# Altium schematic sheet main.SchDoc(main) (continued)

## Library data of the parts
C1 = A759KR227M1VAAE024: Cap, 220Uf, 35V, Alu Polymer, Radial Rohs Compliant: Yes |Kemet A759KR227M1VAAE024; Manufacturer: KEMET; ESR (Equivalent Series Resistance): 24mΩ; Leakage Current: 1.54mA; Ripple Current: 100mA; Value: 220µF; Case/Package: Radial; Category: Aluminum Electrolytic Capacitors; ClassName: 12V_TO_5V_SUPPLY; Component Kind: Standard; ComponentLink1Description: https://datasheet.octopart.com:443/A759KR227M1VAAE024-KEMET-datasheet-182036766.pdf?src-supplier=IHS; ComponentLink1URL: https://datasheet.octopart.com:443/A759KR227M1VAAE024-KEMET-datasheet-182036766.pdf?src-supplier=IHS; ComponentLink2Description: https://datasheet.octopart.com:443/A759KR227M1VAAE024-KEMET-datasheet-181955568.pdf?src-supplier=Farnell; ComponentLink2URL: https://datasheet.octopart.com:443/A759KR227M1VAAE024-KEMET-datasheet-181955568.pdf?src-supplier=Farnell; ComponentLink3Description: https://datasheet.octopart.com:443/A759KR227M1VAAE024-KEMET-datasheet-170333783.pdf?src-supplier=Newark; ComponentLink3URL: https://datasheet.octopart.com:443/A759KR227M1VAAE024-KEMET-datasheet-170333783.pdf?src-supplier=Newark; Diameter: 8mm; Length: 11.5mm; Lifecycle Status: Production; Manufacturer Part Number: A759KR227M1VAAE024; Max Operating Temperature: 125°C; Min Operating Temperature: -55°C; Number of Terminals: 2; Packaging: Bulk; Pricing: 10=0,367, 50=0,348, 100=0,328, 500=0,262, 1000=0,241, 2000=0,236 (EUR); REACH SVHC: Yes; RoHS: Compliant; Stock: 48; Supplier: Farnell; Supplier Part Number: 4071164; Terminal Pitch: 3.5mm; Voltage Rating (DC): 35V; Library Name: IMCODR Components.SchLib
C2 = EEUFC1V221B: Radial Can ALUMINUM ELECTROLYTIC CAPACITOR FC 35V 220muF ±20% 0.394Dia 10.00mm; Manufacturer: Panasonic; ESR (Equivalent Series Resistance): 90mΩ; Lead Diameter: 600µm; Lead/Base Style: Straight; Leakage Current: 3µA; Life (Hours): 3000hours; Material: Aluminium; Polarity: Polar; Ripple Current: 755mA; Value: 220µF; Case/Package: Radial; Category: Aluminum Electrolytic Capacitors; ClassName: 12V_TO_5V_SUPPLY; Component Kind: Standard; ComponentLink1Description: https://datasheet.octopart.com:443/EEU-FC1V221B-Panasonic-datasheet-154766098.pdf?src-supplier=Master+Electronics; ComponentLink1URL: https://datasheet.octopart.com:443/EEU-FC1V221B-Panasonic-datasheet-154766098.pdf?src-supplier=Master+Electronics; ComponentLink2Description: https://datasheet.octopart.com:443/EEU-FC1V221B-Panasonic-datasheet-68300023.pdf?src-supplier=Newark; ComponentLink2URL: https://datasheet.octopart.com:443/EEU-FC1V221B-Panasonic-datasheet-68300023.pdf?src-supplier=Newark; ComponentLink3Description: https://datasheet.octopart.com:443/EEU-FC1V221B-Panasonic-datasheet-13266282.pdf?src-supplier=Electro+Sonic; ComponentLink3URL: https://datasheet.octopart.com:443/EEU-FC1V221B-Panasonic-datasheet-13266282.pdf?src-supplier=Electro+Sonic; ComponentLink4Description: https://datasheet.octopart.com:443/EEU-FC1V221B-Panasonic-datasheet-22087617.pdf?src-supplier=Electro+Sonic; ComponentLink4URL: https://datasheet.octopart.com:443/EEU-FC1V221B-Panasonic-datasheet-22087617.pdf?src-supplier=Electro+Sonic; ComponentLink5Description: https://datasheet.octopart.com:443/EEU-FC1V221B-Panasonic-datasheet-10025751.pdf?src-supplier=RS+(Formerly+Allied+Electronics); ComponentLink5URL: https://datasheet.octopart.com:443/EEU-FC1V221B-Panasonic-datasheet-10025751.pdf?src-supplier=RS+(Formerly+Allied+Electronics); Contact Plating: Tin; Diameter: 10mm; Height: 12.5mm; Height - Seated (Max): 13.462mm; Impedance: 90mΩ; Lead Pitch: 5mm; Length: 12.5mm; Manufacturer Part Number: EEUFC1V221B; Max Operating Temperature: 105°C; Min Operating Temperature: -55°C; Mounting Technology: Through Hole; Pricing: 500=0,2084, 1000=0,188, 2500=0,172, 3000=0,171, 5000=0,161, 10000=0,147 (USD); Radiation Hardening: No; RoHS: Compliant; Schedule B: 8532220020, 8532220020|8532220020|8532220020|8532220020|8532220020; Stock: 17500; Supplier: Arrow Electronics; Supplier Part Number: EEU-FC1V221B; Termination: Radial; Tolerance: 20%; Voltage: 35V; Voltage Rating: 35V; Voltage Rating (DC): 35V; Width: 10mm; Library Name: IMCODR Components.SchLib
C3 = 564R60GAD22: Cera-Mite High Voltage Ceramic Disc Capacitors 2200pF 6Kvolts 20% .375 L.S. Radial; Manufacturer: Vishay; Dielectric: Z5U; Dissipation Factor: 0.2%; Lead Diameter: 810µm; Lead Length: 32mm; Lead/Base Style: Straight; Value: 2.2nF; Voltage: 6kV; Case/Package: Radial; Category: Ceramic Capacitors; China RoHS: Non-Compliant; ClassName: HV_SUPPLY; Component Kind: Standard; ComponentLink1Description: https://datasheet.octopart.com:443/564R60GAD22-Vishay-datasheet-159515080.pdf?src-supplier=IHS; ComponentLink1URL: https://datasheet.octopart.com:443/564R60GAD22-Vishay-datasheet-159515080.pdf?src-supplier=IHS; ComponentLink2Description: https://datasheet.octopart.com:443/564R60GAD22-Vishay-datasheet-81841959.pdf?src-supplier=Upverter; ComponentLink2URL: https://datasheet.octopart.com:443/564R60GAD22-Vishay-datasheet-81841959.pdf?src-supplier=Upverter; ComponentLink3Description: https://datasheet.octopart.com:443/564R60GAD22-Vishay-datasheet-12588008.pdf?src-supplier=element14+APAC; ComponentLink3URL: https://datasheet.octopart.com:443/564R60GAD22-Vishay-datasheet-12588008.pdf?src-supplier=element14+APAC; ComponentLink4Description: https://datasheet.octopart.com:443/564R60GAD22-Vishay-datasheet-62317770.pdf?src-supplier=element14+APAC; ComponentLink4URL: https://datasheet.octopart.com:443/564R60GAD22-Vishay-datasheet-62317770.pdf?src-supplier=element14+APAC; ComponentLink5Description: https://datasheet.octopart.com:443/564R60GAD22-Vishay-datasheet-12521535.pdf?src-supplier=Future+Electronics; ComponentLink5URL: https://datasheet.octopart.com:443/564R60GAD22-Vishay-datasheet-12521535.pdf?src-supplier=Future+Electronics; ComponentLink6Description: https://datasheet.octopart.com:443/564R60GAD22-Vishay-datasheet-8370124.pdf?src-supplier=Future+Electronics; ComponentLink6URL: https://datasheet.octopart.com:443/564R60GAD22-Vishay-datasheet-8370124.pdf?src-supplier=Future+Electronics; ComponentLink7Description: https://datasheet.octopart.com:443/564R60GAD22-Vishay-datasheet-12538201.pdf?src-supplier=Future+Electronics; ComponentLink7URL: https://datasheet.octopart.com:443/564R60GAD22-Vishay-datasheet-12538201.pdf?src-supplier=Future+Electronics; ComponentLink8Description: https://datasheet.octopart.com:443/564R60GAD22-Vishay-datasheet-12511014.pdf?src-supplier=Future+Electronics; ComponentLink8URL: https://datasheet.octopart.com:443/564R60GAD22-Vishay-datasheet-12511014.pdf?src-supplier=Future+Electronics; ComponentLink9Description: https://datasheet.octopart.com:443/564R60GAD22-Vishay-datasheet-8702193.pdf?src-supplier=Future+Electronics; ComponentLink9URL: https://datasheet.octopart.com:443/564R60GAD22-Vishay-datasheet-8702193.pdf?src-supplier=Future+Electronics; ComponentLink10Description: https://datasheet.octopart.com:443/564R60GAD22-Vishay-datasheet-8632260.pdf?src-supplier=Future+Electronics; ComponentLink10URL: https://datasheet.octopart.com:443/564R60GAD22-Vishay-datasheet-8632260.pdf?src-supplier=Future+Electronics; ComponentLink11Description: https://datasheet.octopart.com:443/564R60GAD22-Vishay-datasheet-8562214.pdf?src-supplier=Future+Electronics; ComponentLink11URL: https://datasheet.octopart.com:443/564R60GAD22-Vishay-datasheet-8562214.pdf?src-supplier=Future+Electronics; ComponentLink12Description: https://datasheet.octopart.com:443/564R60GAD22-Vishay-datasheet-555701.pdf?src-supplier=Future+Electronics; ComponentLink12URL: https://datasheet.octopart.com:443/564R60GAD22-Vishay-datasheet-555701.pdf?src-supplier=Future+Electronics; ComponentLink13Description: https://datasheet.octopart.com:443/564R60GAD22-Vishay-datasheet-12545917.pdf?src-supplier=_legacy+Avnet; ComponentLink13URL: https://datasheet.octopart.com:443/564R60GAD22-Vishay-datasheet-12545917.pdf?src-supplier=_legacy+Avnet; ComponentLink14Description: https://datasheet.octopart.com:443/564R60GAD22-Vishay-datasheet-24570279.pdf?src-supplier=Farnell; ComponentLink14URL: https://datasheet.octopart.com:443/564R60GAD22-Vishay-datasheet-24570279.pdf?src-supplier=Farnell; ComponentLink15Description: https://datasheet.octopart.com:443/564R60GAD22-Vishay-datasheet-154299271.pdf?src-supplier=Master+Electronics; ComponentLink15URL: https://datasheet.octopart.com:443/564R60GAD22-Vishay-datasheet-154299271.pdf?src-supplier=Master+Electronics; ComponentLink16Description: https://datasheet.octopart.com:443/564R60GAD22-Vishay-datasheet-21267914.pdf?src-supplier=Newark; ComponentLink16URL: https://datasheet.octopart.com:443/564R60GAD22-Vishay-datasheet-21267914.pdf?src-supplier=Newark; ComponentLink17Description: https://datasheet.octopart.com:443/564R60GAD22-Vishay-datasheet-5316904.pdf?src-supplier=Verical; ComponentLink17URL: https://datasheet.octopart.com:443/564R60GAD22-Vishay-datasheet-5316904.pdf?src-supplier=Verical; ComponentLink18Description: https://datasheet.octopart.com:443/564R60GAD22-Vishay-datasheet-161812.pdf?src-supplier=Mouser; ComponentLink18URL: https://datasheet.octopart.com:443/564R60GAD22-Vishay-datasheet-161812.pdf?src-supplier=Mouser; ComponentLink19Description: http://sigma.octopart.com:80/rohs_statement/Vishay-company-15.pdf?src-supplier=Compliance+Statements; ComponentLink19URL: http://sigma.octopart.com:80/rohs_statement/Vishay-company-15.pdf?src-supplier=Compliance+Statements; Composition: Ceramic; Contact Plating: Tin; Depth: 6.1mm; Diameter: 13.5mm; Dielectric Material: Ceramic; Height: 17.1mm; Height - Seated (Max): 18.288mm; Lead Free: Lead Free; Lead Pitch: 9.5mm; Length: 13.5mm; Lifecycle Status: Production; Manufacturer Part Number: 564R60GAD22; Material: Ceramic; Max Operating Temperature: 105°C; Min Operating Temperature: -25°C; Mounting Technology: PCB, Radial, Through Hole; Number of Terminals: 2; Packaging: Bulk; Pins: 2; Pricing: 1=2, 10=1,01, 100=0,996, 500=0,914, 1000=0,819, 2000=0,803 (EUR); Radiation Hardening: No; REACH SVHC: Yes; RoHS: Compliant; Schedule B: 8532230060; Stock: 10091; Supplier: Farnell; Supplier Part Number: 2395789; Terminal Pitch: 9.5mm; Termination: Radial; Tolerance: 20%; Voltage Rating: 6kV; Voltage Rating (DC): 6kV; Weight: 4.535924g; Width: 6.1mm; Library Name: IMCODR Components.SchLib
C4 C5 C8 C9 = TAJA226M010RNJ: Cap Tant Solid 22uF 10V A CASE 20%( 3.2 X 1.6 X 1.6mm) Inward L SMD 3216-18 3 Ohm 125C T/R; Manufacturer: KYOCERA AVX; Dielectric Material: Tantalum; Dissipation Factor: 8%; ESR (Equivalent Series Resistance): 3Ω; Leakage Current: 2.2µA; Life (Hours): 2000hours; Polarity: Polar; Ripple Current: 63mA; Value: 22µF; Case Code (Imperial): 1206; Case Code (Metric): 3216; Case/Package: 1206; Category: Tantalum Capacitors; China RoHS: Compliant; Component Kind: Standard; ComponentLink1Description: https://datasheet.octopart.com:443/TAJA226M010RNJ-KYOCERA-AVX-datasheet-166923940.pdf?src-supplier=Amar+Radio+Corporation; ComponentLink1URL: https://datasheet.octopart.com:443/TAJA226M010RNJ-KYOCERA-AVX-datasheet-166923940.pdf?src-supplier=Amar+Radio+Corporation; ComponentLink2Description: https://sigma.octopart.com:443/151364110/technical_drawing/AVX-TAJA226M010RNJ.pdf?src-supplier=Upverter; ComponentLink2URL: https://sigma.octopart.com:443/151364110/technical_drawing/AVX-TAJA226M010RNJ.pdf?src-supplier=Upverter; ComponentLink3Description: https://datasheet.octopart.com/TAJA226M010RNJ-AVX---Kyocera-datasheet-8398506.pdf; ComponentLink3URL: https://datasheet.octopart.com/TAJA226M010RNJ-AVX---Kyocera-datasheet-8398506.pdf; ComponentLink4Description: https://datasheet.octopart.com/TAJA226M010RNJ-AVX---Kyocera-datasheet-8359467.pdf; ComponentLink4URL: https://datasheet.octopart.com/TAJA226M010RNJ-AVX---Kyocera-datasheet-8359467.pdf; ComponentLink5Description: https://datasheet.octopart.com/TAJA226M010RNJ-AVX---Kyocera-datasheet-12511273.pdf; ComponentLink5URL: https://datasheet.octopart.com/TAJA226M010RNJ-AVX---Kyocera-datasheet-12511273.pdf; ComponentLink6Description: https://datasheet.octopart.com/TAJ-Series---Standard-Tantalum-AVX-datasheet-family-88.pdf; ComponentLink6URL: https://datasheet.octopart.com/TAJ-Series---Standard-Tantalum-AVX-datasheet-family-88.pdf; ComponentLink7Description: https://datasheet.octopart.com:443/TAJA226M010RNJ-AVX-datasheet-10916590.pdf?src-supplier=Future+Electronics; ComponentLink7URL: https://datasheet.octopart.com:443/TAJA226M010RNJ-AVX-datasheet-10916590.pdf?src-supplier=Future+Electronics; ComponentLink8Description: https://datasheet.octopart.com:443/TAJA226M010RNJ-AVX-datasheet-5393561.pdf?src-supplier=Future+Electronics; ComponentLink8URL: https://datasheet.octopart.com:443/TAJA226M010RNJ-AVX-datasheet-5393561.pdf?src-supplier=Future+Electronics; ComponentLink9Description: https://datasheet.octopart.com:443/TAJA226M010RNJ-AVX-datasheet-5308206.pdf?src-supplier=Future+Electronics; ComponentLink9URL: https://datasheet.octopart.com:443/TAJA226M010RNJ-AVX-datasheet-5308206.pdf?src-supplier=Future+Electronics; ComponentLink10Description: https://datasheet.octopart.com:443/TAJA226M010RNJ-AVX-datasheet-11475269.pdf?src-supplier=Future+Electronics; ComponentLink10URL: https://datasheet.octopart.com:443/TAJA226M010RNJ-AVX-datasheet-11475269.pdf?src-supplier=Future+Electronics; ComponentLink11Description: https://datasheet.octopart.com:443/TAJA226M010RNJ-AVX-datasheet-10851837.pdf?src-supplier=Future+Electronics; ComponentLink11URL: https://datasheet.octopart.com:443/TAJA226M010RNJ-AVX-datasheet-10851837.pdf?src-supplier=Future+Electronics; ComponentLink12Description: https://datasheet.octopart.com:443/TAJA226M010RNJ-AVX-datasheet-10839255.pdf?src-supplier=Future+Electronics; ComponentLink12URL: https://datasheet.octopart.com:443/TAJA226M010RNJ-AVX-datasheet-10839255.pdf?src-supplier=Future+Electronics; ComponentLink13Description: https://datasheet.octopart.com:443/TAJA226M010RNJ-AVX-datasheet-10620092.pdf?src-supplier=Future+Electronics; ComponentLink13URL: https://datasheet.octopart.com:443/TAJA226M010RNJ-AVX-datasheet-10620092.pdf?src-supplier=Future+Electronics; ComponentLink14Description: https://datasheet.octopart.com:443/TAJA226M010RNJ-AVX-datasheet-10545425.pdf?src-supplier=Future+Electronics; ComponentLink14URL: https://datasheet.octopart.com:443/TAJA226M010RNJ-AVX-datasheet-10545425.pdf?src-supplier=Future+Electronics; ComponentLink15Description: https://datasheet.octopart.com:443/TAJA226M010RNJ-AVX-datasheet-10403705.pdf?src-supplier=Future+Electronics; ComponentLink15URL: https://datasheet.octopart.com:443/TAJA226M010RNJ-AVX-datasheet-10403705.pdf?src-supplier=Future+Electronics; ComponentLink16Description: https://datasheet.octopart.com:443/TAJA226M010RNJ-AVX-datasheet-10380087.pdf?src-supplier=Future+Electronics; ComponentLink16URL: https://datasheet.octopart.com:443/TAJA226M010RNJ-AVX-datasheet-10380087.pdf?src-supplier=Future+Electronics; ComponentLink17Description: https://datasheet.octopart.com:443/TAJA226M010RNJ-AVX-datasheet-10285639.pdf?src-supplier=Future+Electronics; ComponentLink17URL: https://datasheet.octopart.com:443/TAJA226M010RNJ-AVX-datasheet-10285639.pdf?src-supplier=Future+Electronics; ComponentLink18Description: https://datasheet.octopart.com:443/TAJA226M010RNJ-AVX-datasheet-10193294.pdf?src-supplier=Future+Electronics; ComponentLink18URL: https://datasheet.octopart.com:443/TAJA226M010RNJ-AVX-datasheet-10193294.pdf?src-supplier=Future+Electronics; ComponentLink19Description: https://datasheet.octopart.com:443/TAJA226M010RNJ-AVX-datasheet-10028080.pdf?src-supplier=Future+Electronics; ComponentLink19URL: https://datasheet.octopart.com:443/TAJA226M010RNJ-AVX-datasheet-10028080.pdf?src-supplier=Future+Electronics; ComponentLink20Description: https://datasheet.octopart.com:443/TAJA226M010RNJ-AVX-datasheet-8877386.pdf?src-supplier=Future+Electronics; ComponentLink20URL: https://datasheet.octopart.com:443/TAJA226M010RNJ-AVX-datasheet-8877386.pdf?src-supplier=Future+Electronics; ComponentLink21Description: https://datasheet.octopart.com:443/TAJA226M010RNJ-AVX-datasheet-8858887.pdf?src-supplier=Future+Electronics; ComponentLink21URL: https://datasheet.octopart.com:443/TAJA226M010RNJ-AVX-datasheet-8858887.pdf?src-supplier=Future+Electronics; ComponentLink22Description: https://datasheet.octopart.com:443/TAJA226M010RNJ-AVX-datasheet-8853265.pdf?src-supplier=Future+Electronics; ComponentLink22URL: https://datasheet.octopart.com:443/TAJA226M010RNJ-AVX-datasheet-8853265.pdf?src-supplier=Future+Electronics; ComponentLink23Description: https://datasheet.octopart.com:443/TAJA226M010RNJ-AVX-datasheet-8836325.pdf?src-supplier=Future+Electronics; ComponentLink23URL: https://datasheet.octopart.com:443/TAJA226M010RNJ-AVX-datasheet-8836325.pdf?src-supplier=Future+Electronics; ComponentLink24Description: https://datasheet.octopart.com:443/TAJA226M010RNJ-AVX-datasheet-8715220.pdf?src-supplier=Future+Electronics; ComponentLink24URL: https://datasheet.octopart.com:443/TAJA226M010RNJ-AVX-datasheet-8715220.pdf?src-supplier=Future+Electronics; ComponentLink25Description: https://datasheet.octopart.com:443/TAJA226M010RNJ-AVX-datasheet-8701730.pdf?src-supplier=Future+Electronics; ComponentLink25URL: https://datasheet.octopart.com:443/TAJA226M010RNJ-AVX-datasheet-8701730.pdf?src-supplier=Future+Electronics; ComponentLink26Description: https://datasheet.octopart.com:443/TAJA226M010RNJ-AVX-datasheet-8621976.pdf?src-supplier=Future+Electronics; ComponentLink26URL: https://datasheet.octopart.com:443/TAJA226M010RNJ-AVX-datasheet-8621976.pdf?src-supplier=Future+Electronics; ComponentLink27Description: https://datasheet.octopart.com:443/TAJA226M010RNJ-AVX-datasheet-8447299.pdf?src-supplier=Future+Electronics; ComponentLink27URL: https://datasheet.octopart.com:443/TAJA226M010RNJ-AVX-datasheet-8447299.pdf?src-supplier=Future+Electronics; ComponentLink28Description: https://datasheet.octopart.com:443/TAJA226M010RNJ-AVX-datasheet-5428838.pdf?src-supplier=Future+Electronics; ComponentLink28URL: https://datasheet.octopart.com:443/TAJA226M010RNJ-AVX-datasheet-5428838.pdf?src-supplier=Future+Electronics; ComponentLink29Description: https://datasheet.octopart.com:443/TAJA226M010RNJ-KYOCERA-AVX-datasheet-158174673.pdf?src-supplier=element14+APAC; ComponentLink29URL: https://datasheet.octopart.com:443/TAJA226M010RNJ-KYOCERA-AVX-datasheet-158174673.pdf?src-supplier=element14+APAC; ComponentLink30Description: https://datasheet.octopart.com:443/TAJA226M010RNJ-AVX-datasheet-16077231.pdf?src-supplier=element14+APAC; ComponentLink30URL: https://datasheet.octopart.com:443/TAJA226M010RNJ-AVX-datasheet-16077231.pdf?src-supplier=element14+APAC; ComponentLink31Description: https://datasheet.octopart.com:443/TAJA226M010RNJ-KYOCERA-AVX-datasheet-62304617.pdf?src-supplier=Newark; ComponentLink31URL: https://datasheet.octopart.com:443/TAJA226M010RNJ-KYOCERA-AVX-datasheet-62304617.pdf?src-supplier=Newark; ComponentLink32Description: https://datasheet.octopart.com:443/TAJA226M010RNJ-AVX-datasheet-8324253.pdf?src-supplier=Newark; ComponentLink32URL: https://datasheet.octopart.com:443/TAJA226M010RNJ-AVX-datasheet-8324253.pdf?src-supplier=Newark; ComponentLink33Description: https://datasheet.octopart.com:443/TAJA226M010RNJ-KYOCERA-AVX-datasheet-50868559.pdf?src-supplier=AVX; ComponentLink33URL: https://datasheet.octopart.com:443/TAJA226M010RNJ-KYOCERA-AVX-datasheet-50868559.pdf?src-supplier=AVX; ComponentLink34Description: https://datasheet.octopart.com:443/TAJA226M010RNJ-KYOCERA-AVX-datasheet-156093874.pdf?src-supplier=TME; ComponentLink34URL: https://datasheet.octopart.com:443/TAJA226M010RNJ-KYOCERA-AVX-datasheet-156093874.pdf?src-supplier=TME; ComponentLink35Description: https://datasheet.octopart.com:443/TAJA226M010RNJ-AVX-datasheet-110600193.pdf?src-supplier=RS+(Formerly+Allied+Electronics); ComponentLink35URL: https://datasheet.octopart.com:443/TAJA226M010RNJ-AVX-datasheet-110600193.pdf?src-supplier=RS+(Formerly+Allied+Electronics); ComponentLink36Description: https://datasheet.octopart.com:443/TAJA226M010RNJ-AVX-datasheet-37470702.pdf?src-supplier=RS+(Formerly+Allied+Electronics); ComponentLink36URL: https://datasheet.octopart.com:443/TAJA226M010RNJ-AVX-datasheet-37470702.pdf?src-supplier=RS+(Formerly+Allied+Electronics); ComponentLink37Description: http://sigma.octopart.com:80/rohs_certificate/TAJ-Series---Standard-Tantalum-AVX-family-89.pdf?src-supplier=Compliance+Statements; ComponentLink37URL: http://sigma.octopart.com:80/rohs_certificate/TAJ-Series---Standard-Tantalum-AVX-family-89.pdf?src-supplier=Compliance+Statements; ComponentLink38Description: http://sigma.octopart.com:80/reach_statement/AVX-company-19.pdf?src-supplier=Compliance+Statements; ComponentLink38URL: http://sigma.octopart.com:80/reach_statement/AVX-company-19.pdf?src-supplier=Compliance+Statements; ComponentLink39Description: http://sigma.octopart.com:80/conflict_mineral_statement/AVX-company-34.pdf?src-supplier=Compliance+Statements; ComponentLink39URL: http://sigma.octopart.com:80/conflict_mineral_statement/AVX-company-34.pdf?src-supplier=Compliance+Statements; Depth: 1.6mm; Features: General Purpose; Height: 1.6mm; Height - Seated (Max): 1.8034mm; Lead Free: Lead Free; Lead Pitch: 1.1mm; Length: 3.2mm; Lifecycle Status: Production; Manufacturer Part Number: TAJA226M010RNJ; Max Operating Temperature: 125°C; Min Operating Temperature: -55°C; Mounting Technology: Surface Mount; Number of Terminals: 2; Pins: 2; Pricing: 2000=0,093 (EUR); Radiation Hardening: No; REACH SVHC: Yes; RoHS: Compliant; Schedule B: 8532210050; Stock: 1900; Supplier: RS; Supplier Part Number: 1354032; Termination: SMD/SMT; Tolerance: 20%; Voltage: 10V; Voltage Rating: 10V; Voltage Rating (DC): 10V; Width: 1.6mm; Library Name: IMCODR Components.SchLib
  C4 C5: ClassName: 12V_TO_5V_ANALOG_SUPPLY
  C8 C9: ClassName: 5V_TO_3V3_SUPPLY
C6 C7 C10 C11 C14-C16 = 0805 SMD Capacitor: Cap Ceramic 0.1uF 100V X7R 20% SMD 0805 125C Plastic T/R; Manufacturer: KEMET; Manufacturer Part Number: C0805C106K9RACTU; Dissipation Factor: 7.5%; Temperature Coefficient: 30ppm/°C; Tolerance: 10%; Value: 10µF; Voltage Rating: 6.3V; Case Code (Imperial): 0805; Case Code (Metric): 2012; Case/Package: 0805; Category: Ceramic Capacitors; China RoHS: Compliant; Component Kind: Standard; ComponentLink1Description: https://datasheet.octopart.com:443/C0805C106K9RACTU-KEMET-datasheet-178445679.pdf?src-supplier=IHS; ComponentLink1URL: https://datasheet.octopart.com:443/C0805C106K9RACTU-KEMET-datasheet-178445679.pdf?src-supplier=IHS; ComponentLink2Description: https://datasheet.octopart.com:443/C0805C106K9RAC7800-KEMET-datasheet-184793276.pdf?src-supplier=IHS; ComponentLink2URL: https://datasheet.octopart.com:443/C0805C106K9RAC7800-KEMET-datasheet-184793276.pdf?src-supplier=IHS; ComponentLink3Description: https://datasheet.octopart.com:443/C0805C106K9RACTU-KEMET-datasheet-137357759.pdf?src-supplier=Farnell; ComponentLink3URL: https://datasheet.octopart.com:443/C0805C106K9RACTU-KEMET-datasheet-137357759.pdf?src-supplier=Farnell; ComponentLink4Description: https://datasheet.octopart.com:443/C0805C106K9RACTU-KEMET-datasheet-100448665.pdf?src-supplier=Farnell; ComponentLink4URL: https://datasheet.octopart.com:443/C0805C106K9RACTU-KEMET-datasheet-100448665.pdf?src-supplier=Farnell; ComponentLink5Description: https://datasheet.octopart.com:443/C0805C106K9RACTU-KEMET-datasheet-74831648.pdf?src-supplier=Farnell; ComponentLink5URL: https://datasheet.octopart.com:443/C0805C106K9RACTU-KEMET-datasheet-74831648.pdf?src-supplier=Farnell; ComponentLink6Description: https://datasheet.octopart.com:443/C0805C106K9RACTU-Kemet-datasheet-66076756.pdf?src-supplier=element14+APAC; ComponentLink6URL: https://datasheet.octopart.com:443/C0805C106K9RACTU-Kemet-datasheet-66076756.pdf?src-supplier=element14+APAC; ComponentLink7Description: https://datasheet.octopart.com:443/C0805C106K9RACTU-Kemet-datasheet-62058920.pdf?src-supplier=element14+APAC; ComponentLink7URL: https://datasheet.octopart.com:443/C0805C106K9RACTU-Kemet-datasheet-62058920.pdf?src-supplier=element14+APAC; ComponentLink8Description: https://datasheet.octopart.com:443/C0805C106K9RACTU-KEMET-datasheet-68331906.pdf?src-supplier=element14+APAC; ComponentLink8URL: https://datasheet.octopart.com:443/C0805C106K9RACTU-KEMET-datasheet-68331906.pdf?src-supplier=element14+APAC; ComponentLink9Description: https://datasheet.octopart.com:443/C0805C106K9RACTU-KEMET-datasheet-176289605.pdf?src-supplier=Newark; ComponentLink9URL: https://datasheet.octopart.com:443/C0805C106K9RACTU-KEMET-datasheet-176289605.pdf?src-supplier=Newark; ComponentLink10Description: https://datasheet.octopart.com:443/C0805C106K9RACTU-KEMET-datasheet-130256537.pdf?src-supplier=Newark; ComponentLink10URL: https://datasheet.octopart.com:443/C0805C106K9RACTU-KEMET-datasheet-130256537.pdf?src-supplier=Newark; ComponentLink11Description: https://datasheet.octopart.com:443/C0805C106K9RACTU-Kemet-datasheet-21527579.pdf?src-supplier=Newark; ComponentLink11URL: https://datasheet.octopart.com:443/C0805C106K9RACTU-Kemet-datasheet-21527579.pdf?src-supplier=Newark; ComponentLink12Description: https://datasheet.octopart.com:443/C0805C106K9RACTU-Kemet-datasheet-17019117.pdf?src-supplier=Newark; ComponentLink12URL: https://datasheet.octopart.com:443/C0805C106K9RACTU-Kemet-datasheet-17019117.pdf?src-supplier=Newark; ComponentLink13Description: https://datasheet.octopart.com:443/C0805C106K9RACTU-KEMET-datasheet-157246124.pdf?src-supplier=TME; ComponentLink13URL: https://datasheet.octopart.com:443/C0805C106K9RACTU-KEMET-datasheet-157246124.pdf?src-supplier=TME; ComponentLink14Description: https://datasheet.octopart.com/C0805C106K9RACTU-KEMET-datasheet-84169754.pdf; ComponentLink14URL: https://datasheet.octopart.com/C0805C106K9RACTU-KEMET-datasheet-84169754.pdf; ComponentLink15Description: https://datasheet.octopart.com:443/C0805C104M1RACTU-KEMET-datasheet-178445679.pdf?src-supplier=IHS; ComponentLink15URL: https://datasheet.octopart.com:443/C0805C104M1RACTU-KEMET-datasheet-178445679.pdf?src-supplier=IHS; ComponentLink16Description: https://datasheet.octopart.com:443/C0805C104M1RAC7800-KEMET-datasheet-184793267.pdf?src-supplier=IHS; ComponentLink16URL: https://datasheet.octopart.com:443/C0805C104M1RAC7800-KEMET-datasheet-184793267.pdf?src-supplier=IHS; ComponentLink17Description: https://datasheet.octopart.com:443/C0805C104M1RACTU-KEMET-datasheet-68331921.pdf?src-supplier=Upverter; ComponentLink17URL: https://datasheet.octopart.com:443/C0805C104M1RACTU-KEMET-datasheet-68331921.pdf?src-supplier=Upverter; ComponentLink18Description: https://datasheet.octopart.com:443/C0805C104M1RACTU-KEMET-datasheet-100087376.pdf?src-supplier=Farnell; ComponentLink18URL: https://datasheet.octopart.com:443/C0805C104M1RACTU-KEMET-datasheet-100087376.pdf?src-supplier=Farnell; ComponentLink19Description: https://datasheet.octopart.com:443/C0805C104M1RACTU-KEMET-datasheet-137357759.pdf?src-supplier=Farnell; ComponentLink19URL: https://datasheet.octopart.com:443/C0805C104M1RACTU-KEMET-datasheet-137357759.pdf?src-supplier=Farnell; ComponentLink20Description: https://datasheet.octopart.com:443/C0805C104M1RACTU-KEMET-datasheet-175423035.pdf?src-supplier=Newark; ComponentLink20URL: https://datasheet.octopart.com:443/C0805C104M1RACTU-KEMET-datasheet-175423035.pdf?src-supplier=Newark; ComponentLink21Description: https://datasheet.octopart.com:443/C0805C104M1RACTU-KEMET-datasheet-182427320.pdf?src-supplier=Newark; ComponentLink21URL: https://datasheet.octopart.com:443/C0805C104M1RACTU-KEMET-datasheet-182427320.pdf?src-supplier=Newark; ComponentLink22Description: https://datasheet.octopart.com/C0805C104M1RACTU-KEMET-datasheet-84169754.pdf; ComponentLink22URL: https://datasheet.octopart.com/C0805C104M1RACTU-KEMET-datasheet-84169754.pdf; ComponentLink23Description: https://datasheet.octopart.com:443/C0805C104M1RACTU-Kemet-datasheet-11798100.pdf?src-supplier=Arrow+Electronics; ComponentLink23URL: https://datasheet.octopart.com:443/C0805C104M1RACTU-Kemet-datasheet-11798100.pdf?src-supplier=Arrow+Electronics; Contact Plating: Tin; Depth: 1.25mm; Dielectric: X7R; Dielectric Material: Ceramic; Height: 1.25mm; Length: 2mm; Lifecycle Status: Production; Material: Ceramic; Max Operating Temperature: 125°C; Min Operating Temperature: -55°C; Mounting Technology: Surface Mount; Number of Terminals: 2; Packaging: Cut Tape; Pricing: 250=0,097 (EUR); REACH SVHC: Yes; RoHS: Compliant; Schedule B: 8532240020, 8532240020|8532240020|8532240020|8532240020|8532240020; Stock: 4750; Supplier: RS; Supplier Part Number: 1806475; Termination: SMD/SMT; Thickness: 1.397mm; Voltage Rating (DC): 6.3V; Width: 1.25mm; Library Name: IMCODR Components.SchLib
  C6 C7: ClassName: HV_CURRENT_SENSE
  C10 C11: ClassName: HV_SUPPLY_MONITOR
  C14: ClassName: HV_DAC
  C15 C16: ClassName: HV_ADC
C12 = 0805 SMD Capacitor: Ceramic Capacitor, Ceramic, 25V, 10% +Tol, 10% -Tol, X7R, 15% TC, 0.15uF, Surface Mount, 0805; Manufacturer: KEMET; Manufacturer Package Identifier: CC0805-0.85; Manufacturer Part Number: C0805C106K9RACTU; Dissipation Factor: 7.5%; Value: 10µF; Voltage Rating: 6.3V; Case Code (Imperial): 0805; Case Code (Metric): 2012; Case/Package: 0805; Category: Ceramic Capacitors; China RoHS: Compliant; ClassName: HV_DAC; Component Kind: Standard; ComponentLink1Description: https://datasheet.octopart.com:443/C0805C106K9RACTU-KEMET-datasheet-178445679.pdf?src-supplier=IHS; ComponentLink1URL: https://datasheet.octopart.com:443/C0805C106K9RACTU-KEMET-datasheet-178445679.pdf?src-supplier=IHS; ComponentLink2Description: https://datasheet.octopart.com:443/C0805C106K9RAC7800-KEMET-datasheet-184793276.pdf?src-supplier=IHS; ComponentLink2URL: https://datasheet.octopart.com:443/C0805C106K9RAC7800-KEMET-datasheet-184793276.pdf?src-supplier=IHS; ComponentLink3Description: https://datasheet.octopart.com:443/C0805C106K9RACTU-KEMET-datasheet-137357759.pdf?src-supplier=Farnell; ComponentLink3URL: https://datasheet.octopart.com:443/C0805C106K9RACTU-KEMET-datasheet-137357759.pdf?src-supplier=Farnell; ComponentLink4Description: https://datasheet.octopart.com:443/C0805C106K9RACTU-KEMET-datasheet-100448665.pdf?src-supplier=Farnell; ComponentLink4URL: https://datasheet.octopart.com:443/C0805C106K9RACTU-KEMET-datasheet-100448665.pdf?src-supplier=Farnell; ComponentLink5Description: https://datasheet.octopart.com:443/C0805C106K9RACTU-KEMET-datasheet-74831648.pdf?src-supplier=Farnell; ComponentLink5URL: https://datasheet.octopart.com:443/C0805C106K9RACTU-KEMET-datasheet-74831648.pdf?src-supplier=Farnell; ComponentLink6Description: https://datasheet.octopart.com:443/C0805C106K9RACTU-Kemet-datasheet-66076756.pdf?src-supplier=element14+APAC; ComponentLink6URL: https://datasheet.octopart.com:443/C0805C106K9RACTU-Kemet-datasheet-66076756.pdf?src-supplier=element14+APAC; ComponentLink7Description: https://datasheet.octopart.com:443/C0805C106K9RACTU-Kemet-datasheet-62058920.pdf?src-supplier=element14+APAC; ComponentLink7URL: https://datasheet.octopart.com:443/C0805C106K9RACTU-Kemet-datasheet-62058920.pdf?src-supplier=element14+APAC; ComponentLink8Description: https://datasheet.octopart.com:443/C0805C106K9RACTU-KEMET-datasheet-68331906.pdf?src-supplier=element14+APAC; ComponentLink8URL: https://datasheet.octopart.com:443/C0805C106K9RACTU-KEMET-datasheet-68331906.pdf?src-supplier=element14+APAC; ComponentLink9Description: https://datasheet.octopart.com:443/C0805C106K9RACTU-KEMET-datasheet-176289605.pdf?src-supplier=Newark; ComponentLink9URL: https://datasheet.octopart.com:443/C0805C106K9RACTU-KEMET-datasheet-176289605.pdf?src-supplier=Newark; ComponentLink10Description: https://datasheet.octopart.com:443/C0805C106K9RACTU-KEMET-datasheet-130256537.pdf?src-supplier=Newark; ComponentLink10URL: https://datasheet.octopart.com:443/C0805C106K9RACTU-KEMET-datasheet-130256537.pdf?src-supplier=Newark; ComponentLink11Description: https://datasheet.octopart.com:443/C0805C106K9RACTU-Kemet-datasheet-21527579.pdf?src-supplier=Newark; ComponentLink11URL: https://datasheet.octopart.com:443/C0805C106K9RACTU-Kemet-datasheet-21527579.pdf?src-supplier=Newark; ComponentLink12Description: https://datasheet.octopart.com:443/C0805C106K9RACTU-Kemet-datasheet-17019117.pdf?src-supplier=Newark; ComponentLink12URL: https://datasheet.octopart.com:443/C0805C106K9RACTU-Kemet-datasheet-17019117.pdf?src-supplier=Newark; ComponentLink13Description: https://datasheet.octopart.com:443/C0805C106K9RACTU-KEMET-datasheet-157246124.pdf?src-supplier=TME; ComponentLink13URL: https://datasheet.octopart.com:443/C0805C106K9RACTU-KEMET-datasheet-157246124.pdf?src-supplier=TME; ComponentLink14Description: https://datasheet.octopart.com/C0805C106K9RACTU-KEMET-datasheet-84169754.pdf; ComponentLink14URL: https://datasheet.octopart.com/C0805C106K9RACTU-KEMET-datasheet-84169754.pdf; ComponentLink15Description: https://datasheet.octopart.com:443/CC0805KRX7R8BB154-Yageo-datasheet-177165144.pdf?src-supplier=IHS; ComponentLink15URL: https://datasheet.octopart.com:443/CC0805KRX7R8BB154-Yageo-datasheet-177165144.pdf?src-supplier=IHS; ComponentLink16Description: https://datasheet.octopart.com:443/CC0805KRX7R8BB154-Yageo-datasheet-78855689.pdf?src-supplier=Upverter; ComponentLink16URL: https://datasheet.octopart.com:443/CC0805KRX7R8BB154-Yageo-datasheet-78855689.pdf?src-supplier=Upverter; ComponentLink17Description: https://datasheet.octopart.com:443/CC0805KRX7R8BB154-Yageo-datasheet-181969812.pdf?src-supplier=Newark; ComponentLink17URL: https://datasheet.octopart.com:443/CC0805KRX7R8BB154-Yageo-datasheet-181969812.pdf?src-supplier=Newark; ComponentLink18Description: https://datasheet.octopart.com:443/CC0805KRX7R8BB154-Yageo-datasheet-166511530.pdf?src-supplier=Future+Electronics; ComponentLink18URL: https://datasheet.octopart.com:443/CC0805KRX7R8BB154-Yageo-datasheet-166511530.pdf?src-supplier=Future+Electronics; ComponentLink19Description: https://datasheet.octopart.com:443/CC0805KRX7R8BB154-Yageo-datasheet-137700082.pdf?src-supplier=Future+Electronics; ComponentLink19URL: https://datasheet.octopart.com:443/CC0805KRX7R8BB154-Yageo-datasheet-137700082.pdf?src-supplier=Future+Electronics; ComponentLink20Description: https://datasheet.octopart.com:443/CC0805KRX7R8BB154-Yageo-datasheet-137700084.pdf?src-supplier=Future+Electronics; ComponentLink20URL: https://datasheet.octopart.com:443/CC0805KRX7R8BB154-Yageo-datasheet-137700084.pdf?src-supplier=Future+Electronics; ComponentLink21Description: https://datasheet.octopart.com:443/CC0805KRX7R8BB154-Yageo-datasheet-27626582.pdf?src-supplier=Future+Electronics; ComponentLink21URL: https://datasheet.octopart.com:443/CC0805KRX7R8BB154-Yageo-datasheet-27626582.pdf?src-supplier=Future+Electronics; ComponentLink22Description: https://datasheet.octopart.com:443/CC0805KRX7R8BB154-Yageo-datasheet-151948686.pdf?src-supplier=TME; ComponentLink22URL: https://datasheet.octopart.com:443/CC0805KRX7R8BB154-Yageo-datasheet-151948686.pdf?src-supplier=TME; ComponentLink23Description: https://datasheet.octopart.com/CC0805KRX7R8BB154-Yageo-datasheet-10883670.pdf; ComponentLink23URL: https://datasheet.octopart.com/CC0805KRX7R8BB154-Yageo-datasheet-10883670.pdf; ComponentLink24Description: http://sigma.octopart.com:80/conflict_mineral_statement/Yageo-company-3.pdf?src-supplier=Compliance+Statements; ComponentLink24URL: http://sigma.octopart.com:80/conflict_mineral_statement/Yageo-company-3.pdf?src-supplier=Compliance+Statements; ComponentLink25Description: http://sigma.octopart.com:80/rohs_statement/Yageo-company-2.pdf?src-supplier=Compliance+Statements; ComponentLink25URL: http://sigma.octopart.com:80/rohs_statement/Yageo-company-2.pdf?src-supplier=Compliance+Statements; Composition: Ceramic; Depth: 1.25mm; Dielectric: X7R; Dielectric Material: Ceramic; Halogen Free: Halogen Free; Height: 1.25mm; Lead Free: Lead Free; Length: 2mm; Lifecycle Status: Production; Material: Ceramic; Max Operating Temperature: 125°C; Min Operating Temperature: -55°C; Mounting Technology: Surface Mount; Number of Terminals: 2; Packaging: Cut Tape; Pricing: 250=0,097 (EUR); Radiation Hardening: No; REACH SVHC: Yes; RoHS: Compliant; Schedule B: 8532240020, 8532240020|8532240020|8532240020|8532240020|8532240020; Stock: 4750; Supplier: RS; Supplier Part Number: 1806475; Termination: SMD/SMT; Thickness: 1.397mm; Tolerance: 10%; Voltage Rating (DC): 6.3V; Weight: 5.499807mg; Width: 1.25mm; Library Name: IMCODR Components.SchLib
C13 = 0805 SMD Capacitor: Ceramic Capacitor, Ceramic, 16V, 10% +Tol, 10% -Tol, X7R, 15% TC, 1uF, Surface Mount, 0805; Manufacturer: KEMET; Manufacturer Package Identifier: CC0805-0.85; Manufacturer Part Number: C0805C106K9RACTU; Dissipation Factor: 7.5%; Value: 10µF; Voltage Rating: 6.3V; Case Code (Imperial): 0805; Case Code (Metric): 2012; Case/Package: 0805; Category: Ceramic Capacitors; China RoHS: Compliant; ClassName: HV_DAC; Component Kind: Standard; ComponentLink1Description: https://datasheet.octopart.com:443/C0805C106K9RACTU-KEMET-datasheet-178445679.pdf?src-supplier=IHS; ComponentLink1URL: https://datasheet.octopart.com:443/C0805C106K9RACTU-KEMET-datasheet-178445679.pdf?src-supplier=IHS; ComponentLink2Description: https://datasheet.octopart.com:443/C0805C106K9RAC7800-KEMET-datasheet-184793276.pdf?src-supplier=IHS; ComponentLink2URL: https://datasheet.octopart.com:443/C0805C106K9RAC7800-KEMET-datasheet-184793276.pdf?src-supplier=IHS; ComponentLink3Description: https://datasheet.octopart.com:443/C0805C106K9RACTU-KEMET-datasheet-137357759.pdf?src-supplier=Farnell; ComponentLink3URL: https://datasheet.octopart.com:443/C0805C106K9RACTU-KEMET-datasheet-137357759.pdf?src-supplier=Farnell; ComponentLink4Description: https://datasheet.octopart.com:443/C0805C106K9RACTU-KEMET-datasheet-100448665.pdf?src-supplier=Farnell; ComponentLink4URL: https://datasheet.octopart.com:443/C0805C106K9RACTU-KEMET-datasheet-100448665.pdf?src-supplier=Farnell; ComponentLink5Description: https://datasheet.octopart.com:443/C0805C106K9RACTU-KEMET-datasheet-74831648.pdf?src-supplier=Farnell; ComponentLink5URL: https://datasheet.octopart.com:443/C0805C106K9RACTU-KEMET-datasheet-74831648.pdf?src-supplier=Farnell; ComponentLink6Description: https://datasheet.octopart.com:443/C0805C106K9RACTU-Kemet-datasheet-66076756.pdf?src-supplier=element14+APAC; ComponentLink6URL: https://datasheet.octopart.com:443/C0805C106K9RACTU-Kemet-datasheet-66076756.pdf?src-supplier=element14+APAC; ComponentLink7Description: https://datasheet.octopart.com:443/C0805C106K9RACTU-Kemet-datasheet-62058920.pdf?src-supplier=element14+APAC; ComponentLink7URL: https://datasheet.octopart.com:443/C0805C106K9RACTU-Kemet-datasheet-62058920.pdf?src-supplier=element14+APAC; ComponentLink8Description: https://datasheet.octopart.com:443/C0805C106K9RACTU-KEMET-datasheet-68331906.pdf?src-supplier=element14+APAC; ComponentLink8URL: https://datasheet.octopart.com:443/C0805C106K9RACTU-KEMET-datasheet-68331906.pdf?src-supplier=element14+APAC; ComponentLink9Description: https://datasheet.octopart.com:443/C0805C106K9RACTU-KEMET-datasheet-176289605.pdf?src-supplier=Newark; ComponentLink9URL: https://datasheet.octopart.com:443/C0805C106K9RACTU-KEMET-datasheet-176289605.pdf?src-supplier=Newark; ComponentLink10Description: https://datasheet.octopart.com:443/C0805C106K9RACTU-KEMET-datasheet-130256537.pdf?src-supplier=Newark; ComponentLink10URL: https://datasheet.octopart.com:443/C0805C106K9RACTU-KEMET-datasheet-130256537.pdf?src-supplier=Newark; ComponentLink11Description: https://datasheet.octopart.com:443/C0805C106K9RACTU-Kemet-datasheet-21527579.pdf?src-supplier=Newark; ComponentLink11URL: https://datasheet.octopart.com:443/C0805C106K9RACTU-Kemet-datasheet-21527579.pdf?src-supplier=Newark; ComponentLink12Description: https://datasheet.octopart.com:443/C0805C106K9RACTU-Kemet-datasheet-17019117.pdf?src-supplier=Newark; ComponentLink12URL: https://datasheet.octopart.com:443/C0805C106K9RACTU-Kemet-datasheet-17019117.pdf?src-supplier=Newark; ComponentLink13Description: https://datasheet.octopart.com:443/C0805C106K9RACTU-KEMET-datasheet-157246124.pdf?src-supplier=TME; ComponentLink13URL: https://datasheet.octopart.com:443/C0805C106K9RACTU-KEMET-datasheet-157246124.pdf?src-supplier=TME; ComponentLink14Description: https://datasheet.octopart.com/C0805C106K9RACTU-KEMET-datasheet-84169754.pdf; ComponentLink14URL: https://datasheet.octopart.com/C0805C106K9RACTU-KEMET-datasheet-84169754.pdf; ComponentLink15Description: https://datasheet.octopart.com:443/CC0805KFX7R7BB105-Yageo-datasheet-177165144.pdf?src-supplier=IHS; ComponentLink15URL: https://datasheet.octopart.com:443/CC0805KFX7R7BB105-Yageo-datasheet-177165144.pdf?src-supplier=IHS; ComponentLink16Description: https://datasheet.octopart.com:443/CC0805KFX7R7BB105-Yageo-datasheet-78855689.pdf?src-supplier=Upverter; ComponentLink16URL: https://datasheet.octopart.com:443/CC0805KFX7R7BB105-Yageo-datasheet-78855689.pdf?src-supplier=Upverter; ComponentLink17Description: https://datasheet.octopart.com:443/CC0805KFX7R7BB105-Yageo-datasheet-181969529.pdf?src-supplier=Farnell; ComponentLink17URL: https://datasheet.octopart.com:443/CC0805KFX7R7BB105-Yageo-datasheet-181969529.pdf?src-supplier=Farnell; ComponentLink18Description: https://datasheet.octopart.com:443/CC0805KFX7R7BB105-Yageo-datasheet-151948686.pdf?src-supplier=TME; ComponentLink18URL: https://datasheet.octopart.com:443/CC0805KFX7R7BB105-Yageo-datasheet-151948686.pdf?src-supplier=TME; ComponentLink19Description: https://datasheet.octopart.com:443/CC0805KFX7R7BB105-Yageo-datasheet-147453679.pdf?src-supplier=Future+Electronics; ComponentLink19URL: https://datasheet.octopart.com:443/CC0805KFX7R7BB105-Yageo-datasheet-147453679.pdf?src-supplier=Future+Electronics; ComponentLink20Description: https://datasheet.octopart.com/CC0805KFX7R7BB105-Yageo-datasheet-10883670.pdf; ComponentLink20URL: https://datasheet.octopart.com/CC0805KFX7R7BB105-Yageo-datasheet-10883670.pdf; ComponentLink21Description: http://sigma.octopart.com:80/conflict_mineral_statement/Yageo-company-3.pdf?src-supplier=Compliance+Statements; ComponentLink21URL: http://sigma.octopart.com:80/conflict_mineral_statement/Yageo-company-3.pdf?src-supplier=Compliance+Statements; ComponentLink22Description: http://sigma.octopart.com:80/rohs_statement/Yageo-company-2.pdf?src-supplier=Compliance+Statements; ComponentLink22URL: http://sigma.octopart.com:80/rohs_statement/Yageo-company-2.pdf?src-supplier=Compliance+Statements; Composition: Ceramic; Depth: 1.25mm; Dielectric: X7R; Dielectric Material: Ceramic; Halogen Free: Halogen Free; Height: 1.25mm; Lead Free: Lead Free; Length: 2mm; Lifecycle Status: Production; Material: Ceramic; Max Operating Temperature: 125°C; Min Operating Temperature: -55°C; Mounting Technology: Surface Mount; Number of Terminals: 2; Packaging: Cut Tape; Pricing: 250=0,097 (EUR); REACH SVHC: Yes; RoHS: Compliant; Schedule B: 8532240020, 8532240020|8532240020|8532240020|8532240020|8532240020; Stock: 4750; Supplier: RS; Supplier Part Number: 1806475; Termination: SMD/SMT; Thickness: 1.397mm; Tolerance: 10%; Voltage Rating (DC): 6.3V; Weight: 5.499807mg; Width: 1.25mm; Library Name: IMCODR Components.SchLib
C17-C19 = 0805 SMD Capacitor: Ceramic Capacitor, Multilayer, Ceramic, 25V, 10% +Tol, 10% -Tol, X7R, 15% TC, 0.01uF, Surface Mount, 0805; Manufacturer: KEMET; Manufacturer Part Number: C0805C106K9RACTU; Dissipation Factor: 7.5%; Temperature Coefficient: 30ppm/°C; Value: 10µF; Voltage Rating: 6.3V; Case Code (Imperial): 0805; Case Code (Metric): 2012; Case/Package: 0805; Category: Ceramic Capacitors; China RoHS: Compliant; ClassName: ROTARY_ENCODER; Component Kind: Standard; ComponentLink1Description: https://datasheet.octopart.com:443/C0805C106K9RACTU-KEMET-datasheet-178445679.pdf?src-supplier=IHS; ComponentLink1URL: https://datasheet.octopart.com:443/C0805C106K9RACTU-KEMET-datasheet-178445679.pdf?src-supplier=IHS; ComponentLink2Description: https://datasheet.octopart.com:443/C0805C106K9RAC7800-KEMET-datasheet-184793276.pdf?src-supplier=IHS; ComponentLink2URL: https://datasheet.octopart.com:443/C0805C106K9RAC7800-KEMET-datasheet-184793276.pdf?src-supplier=IHS; ComponentLink3Description: https://datasheet.octopart.com:443/C0805C106K9RACTU-KEMET-datasheet-137357759.pdf?src-supplier=Farnell; ComponentLink3URL: https://datasheet.octopart.com:443/C0805C106K9RACTU-KEMET-datasheet-137357759.pdf?src-supplier=Farnell; ComponentLink4Description: https://datasheet.octopart.com:443/C0805C106K9RACTU-KEMET-datasheet-100448665.pdf?src-supplier=Farnell; ComponentLink4URL: https://datasheet.octopart.com:443/C0805C106K9RACTU-KEMET-datasheet-100448665.pdf?src-supplier=Farnell; ComponentLink5Description: https://datasheet.octopart.com:443/C0805C106K9RACTU-KEMET-datasheet-74831648.pdf?src-supplier=Farnell; ComponentLink5URL: https://datasheet.octopart.com:443/C0805C106K9RACTU-KEMET-datasheet-74831648.pdf?src-supplier=Farnell; ComponentLink6Description: https://datasheet.octopart.com:443/C0805C106K9RACTU-Kemet-datasheet-66076756.pdf?src-supplier=element14+APAC; ComponentLink6URL: https://datasheet.octopart.com:443/C0805C106K9RACTU-Kemet-datasheet-66076756.pdf?src-supplier=element14+APAC; ComponentLink7Description: https://datasheet.octopart.com:443/C0805C106K9RACTU-Kemet-datasheet-62058920.pdf?src-supplier=element14+APAC; ComponentLink7URL: https://datasheet.octopart.com:443/C0805C106K9RACTU-Kemet-datasheet-62058920.pdf?src-supplier=element14+APAC; ComponentLink8Description: https://datasheet.octopart.com:443/C0805C106K9RACTU-KEMET-datasheet-68331906.pdf?src-supplier=element14+APAC; ComponentLink8URL: https://datasheet.octopart.com:443/C0805C106K9RACTU-KEMET-datasheet-68331906.pdf?src-supplier=element14+APAC; ComponentLink9Description: https://datasheet.octopart.com:443/C0805C106K9RACTU-KEMET-datasheet-176289605.pdf?src-supplier=Newark; ComponentLink9URL: https://datasheet.octopart.com:443/C0805C106K9RACTU-KEMET-datasheet-176289605.pdf?src-supplier=Newark; ComponentLink10Description: https://datasheet.octopart.com:443/C0805C106K9RACTU-KEMET-datasheet-130256537.pdf?src-supplier=Newark; ComponentLink10URL: https://datasheet.octopart.com:443/C0805C106K9RACTU-KEMET-datasheet-130256537.pdf?src-supplier=Newark; ComponentLink11Description: https://datasheet.octopart.com:443/C0805C106K9RACTU-Kemet-datasheet-21527579.pdf?src-supplier=Newark; ComponentLink11URL: https://datasheet.octopart.com:443/C0805C106K9RACTU-Kemet-datasheet-21527579.pdf?src-supplier=Newark; ComponentLink12Description: https://datasheet.octopart.com:443/C0805C106K9RACTU-Kemet-datasheet-17019117.pdf?src-supplier=Newark; ComponentLink12URL: https://datasheet.octopart.com:443/C0805C106K9RACTU-Kemet-datasheet-17019117.pdf?src-supplier=Newark; ComponentLink13Description: https://datasheet.octopart.com:443/C0805C106K9RACTU-KEMET-datasheet-157246124.pdf?src-supplier=TME; ComponentLink13URL: https://datasheet.octopart.com:443/C0805C106K9RACTU-KEMET-datasheet-157246124.pdf?src-supplier=TME; ComponentLink14Description: https://datasheet.octopart.com/C0805C106K9RACTU-KEMET-datasheet-84169754.pdf; ComponentLink14URL: https://datasheet.octopart.com/C0805C106K9RACTU-KEMET-datasheet-84169754.pdf; ComponentLink15Description: https://datasheet.octopart.com:443/C0805C103K3RACAUTO-KEMET-datasheet-178087915.pdf?src-supplier=IHS; ComponentLink15URL: https://datasheet.octopart.com:443/C0805C103K3RACAUTO-KEMET-datasheet-178087915.pdf?src-supplier=IHS; ComponentLink16Description: https://datasheet.octopart.com:443/C0805C103K3RACAUTO-KEMET-datasheet-68331921.pdf?src-supplier=Upverter; ComponentLink16URL: https://datasheet.octopart.com:443/C0805C103K3RACAUTO-KEMET-datasheet-68331921.pdf?src-supplier=Upverter; ComponentLink17Description: https://datasheet.octopart.com:443/C0805C103K3RACAUTO-KEMET-datasheet-149523797.pdf?src-supplier=Farnell; ComponentLink17URL: https://datasheet.octopart.com:443/C0805C103K3RACAUTO-KEMET-datasheet-149523797.pdf?src-supplier=Farnell; ComponentLink18Description: https://datasheet.octopart.com:443/C0805C103K3RACAUTO-KEMET-datasheet-138896278.pdf?src-supplier=Farnell; ComponentLink18URL: https://datasheet.octopart.com:443/C0805C103K3RACAUTO-KEMET-datasheet-138896278.pdf?src-supplier=Farnell; ComponentLink19Description: https://datasheet.octopart.com/C0805C103K3RACAUTO-KEMET-datasheet-84169754.pdf; ComponentLink19URL: https://datasheet.octopart.com/C0805C103K3RACAUTO-KEMET-datasheet-84169754.pdf; Depth: 1.25mm; Dielectric: X7R; Dielectric Material: Ceramic; Height: 1.25mm; Length: 2mm; Lifecycle Status: Production; Material: Ceramic; Max Operating Temperature: 125°C; Min Operating Temperature: -55°C; Mounting Technology: Surface Mount; Number of Terminals: 2; Packaging: Cut Tape; Pricing: 250=0,097 (EUR); REACH SVHC: Yes; RoHS: Compliant; Schedule B: 8532240020, 8532240020|8532240020|8532240020|8532240020|8532240020; Stock: 4750; Supplier: RS; Supplier Part Number: 1806475; Termination: SMD/SMT; Thickness: 1.397mm; Tolerance: 10%; Voltage Rating (DC): 6.3V; Width: 1.25mm; Library Name: IMCODR Components.SchLib
D1 = B340LB-E3/52T: Rectifier Diode, Schottky, 1 Phase, 1 Element, 3A, 40V V(RRM), Silicon, DO-214AA; Manufacturer: Vishay; Element Configuration: Single; Forward Voltage: 380mV; Max Forward Surge Current (Ifsm): 100A; Max Junction Temperature (Tj): 150°C; Max Reverse Leakage Current: 400µA; Number of Elements: 1; Average Rectified Current: 3A; Case/Package: SMB; Category: Schottky Diodes; China RoHS: Non-Compliant; ClassName: 12V_TO_5V_SUPPLY; Component Kind: Standard; ComponentLink1Description: https://datasheet.octopart.com:443/B340LB-E3-52T-Vishay-datasheet-170327050.pdf?src-supplier=Upverter; ComponentLink1URL: https://datasheet.octopart.com:443/B340LB-E3-52T-Vishay-datasheet-170327050.pdf?src-supplier=Upverter; ComponentLink2Description: https://sigma.octopart.com:443/138898532/technical_drawing/Vishay-B340LB-E3-52T.pdf?src-supplier=Upverter; ComponentLink2URL: https://sigma.octopart.com:443/138898532/technical_drawing/Vishay-B340LB-E3-52T.pdf?src-supplier=Upverter; ComponentLink3Description: https://datasheet.octopart.com:443/B340LB-E3-52T-Vishay-datasheet-181466708.pdf?src-supplier=Newark; ComponentLink3URL: https://datasheet.octopart.com:443/B340LB-E3-52T-Vishay-datasheet-181466708.pdf?src-supplier=Newark; ComponentLink4Description: https://datasheet.octopart.com:443/B340LB-E3-52T-Vishay-datasheet-12554212.pdf?src-supplier=Newark; ComponentLink4URL: https://datasheet.octopart.com:443/B340LB-E3-52T-Vishay-datasheet-12554212.pdf?src-supplier=Newark; ComponentLink5Description: https://datasheet.octopart.com:443/B340LB-E3-52T-Vishay-datasheet-78833474.pdf?src-supplier=Newark; ComponentLink5URL: https://datasheet.octopart.com:443/B340LB-E3-52T-Vishay-datasheet-78833474.pdf?src-supplier=Newark; ComponentLink6Description: https://datasheet.octopart.com:443/B340LB-E3-52T-Vishay-datasheet-41571137.pdf?src-supplier=Newark; ComponentLink6URL: https://datasheet.octopart.com:443/B340LB-E3-52T-Vishay-datasheet-41571137.pdf?src-supplier=Newark; ComponentLink7Description: https://datasheet.octopart.com:443/B340LB-E3-52T-Vishay-Siliconix-datasheet-62309163.pdf?src-supplier=RS+(Formerly+Allied+Electronics); ComponentLink7URL: https://datasheet.octopart.com:443/B340LB-E3-52T-Vishay-Siliconix-datasheet-62309163.pdf?src-supplier=RS+(Formerly+Allied+Electronics); ComponentLink8Description: https://datasheet.octopart.com:443/B340LB-E3/52T-Vishay-datasheet-11748361.pdf?src-supplier=Arrow+Electronics; ComponentLink8URL: https://datasheet.octopart.com:443/B340LB-E3/52T-Vishay-datasheet-11748361.pdf?src-supplier=Arrow+Electronics; ComponentLink9Description: http://sigma.octopart.com:80/rohs_statement/Vishay-company-15.pdf?src-supplier=Compliance+Statements; ComponentLink9URL: http://sigma.octopart.com:80/rohs_statement/Vishay-company-15.pdf?src-supplier=Compliance+Statements; Forward Current: 3A; Height: 2.44mm; Lead Free: Lead Free; Lifecycle Status: Production; Manufacturer Part Number: B340LB-E3/52T; Max Operating Temperature: 150°C; Max Output current: 3A; Max Repetitive Reverse Voltage (Vrrm): 40V; Max Reverse Voltage (DC): 40V; Max Surge Current: 100A; Min Operating Temperature: -65°C; Mounting Technology: Surface Mount; Number of Terminals: 2; Peak Non-Repetitive Surge Current: 100A; Peak Reverse Current: 400µA; Pins: 2; Pricing: 50=0,3, 250=0,27, 500=0,255, 1250=0,246, 2500=0,24 (EUR); Radiation Hardening: No; REACH SVHC: No; Reverse Standoff Voltage: 40V; Reverse Voltage: 40V; RoHS: Compliant; Schedule B: 8541100080, 8541100080|8541100080|8541100080|8541100080|8541100080; Stock: 2850; Supplier: RS; Supplier Part Number: 8151143; Library Name: IMCODR Components.SchLib
D2 = SB530-E3/54: Rectifier Diode, Schottky, 1 Phase, 1 Element, 5A, 30V V(RRM), Silicon, DO-201AD; Manufacturer: Vishay; Element Configuration: Single; Forward Voltage: 480mV; Max Forward Surge Current (Ifsm): 220A; Max Reverse Leakage Current: 500µA; Number of Elements: 1; Peak Non-Repetitive Surge Current: 30A; Peak Reverse Current: 5µA; Polarity: Standard; Reverse Recovery Time: 2µs; Average Rectified Current: 5A; Category: Rectifier Diodes; China RoHS: Non-Compliant; ClassName: 12V_TO_5V_SUPPLY; Component Kind: Standard; ComponentLink1Description: https://datasheet.octopart.com:443/SB530-E3-54-Vishay-datasheet-181470691.pdf?src-supplier=Newark; ComponentLink1URL: https://datasheet.octopart.com:443/SB530-E3-54-Vishay-datasheet-181470691.pdf?src-supplier=Newark; ComponentLink2Description: https://datasheet.octopart.com:443/SB530-E3-54-Vishay-datasheet-11815677.pdf?src-supplier=Newark; ComponentLink2URL: https://datasheet.octopart.com:443/SB530-E3-54-Vishay-datasheet-11815677.pdf?src-supplier=Newark; ComponentLink3Description: https://datasheet.octopart.com:443/SB530-E3-54-Vishay-datasheet-5334050.pdf?src-supplier=Newark; ComponentLink3URL: https://datasheet.octopart.com:443/SB530-E3-54-Vishay-datasheet-5334050.pdf?src-supplier=Newark; ComponentLink4Description: https://datasheet.octopart.com:443/SB530-E3-54-Vishay-datasheet-62318501.pdf?src-supplier=Newark; ComponentLink4URL: https://datasheet.octopart.com:443/SB530-E3-54-Vishay-datasheet-62318501.pdf?src-supplier=Newark; ComponentLink5Description: https://datasheet.octopart.com:443/SB530-E3-54-Vishay-datasheet-41303647.pdf?src-supplier=Newark; ComponentLink5URL: https://datasheet.octopart.com:443/SB530-E3-54-Vishay-datasheet-41303647.pdf?src-supplier=Newark; ComponentLink6Description: https://datasheet.octopart.com:443/SB530-E3-54-Vishay-datasheet-8815874.pdf?src-supplier=RS+(Formerly+Allied+Electronics); ComponentLink6URL: https://datasheet.octopart.com:443/SB530-E3-54-Vishay-datasheet-8815874.pdf?src-supplier=RS+(Formerly+Allied+Electronics); ComponentLink7Description: https://datasheet.octopart.com:443/SB530-E3-54-Vishay-datasheet-41195632.pdf?src-supplier=Farnell; ComponentLink7URL: https://datasheet.octopart.com:443/SB530-E3-54-Vishay-datasheet-41195632.pdf?src-supplier=Farnell; ComponentLink8Description: https://datasheet.octopart.com:443/SB530-E3-54-Vishay-datasheet-122911.pdf?src-supplier=Future+Electronics; ComponentLink8URL: https://datasheet.octopart.com:443/SB530-E3-54-Vishay-datasheet-122911.pdf?src-supplier=Future+Electronics; ComponentLink9Description: http://sigma.octopart.com:80/rohs_statement/Vishay-company-15.pdf?src-supplier=Compliance+Statements; ComponentLink9URL: http://sigma.octopart.com:80/rohs_statement/Vishay-company-15.pdf?src-supplier=Compliance+Statements; Diameter: 5.3mm; Forward Current: 5A; Length: 9.4996mm; Lifecycle Status: Production; Manufacturer Part Number: SB530-E3/54; Max Operating Temperature: 150°C; Max Output current: 5A; Max Repetitive Reverse Voltage (Vrrm): 30V; Max Reverse Voltage (DC): 30V; Max Surge Current: 220A; Min Operating Temperature: -65°C; Mounting Technology: Surface Mount, Through Hole; Number of Terminals: 2; Output Current: 5A; Packaging: Cut Tape; Pins: 2; Pricing: 1=0,583, 10=0,393, 100=0,376, 500=0,367, 1000=0,358, 5000=0,349 (EUR); Radiation Hardening: No; REACH SVHC: No; Reverse Standoff Voltage: 30V; RoHS: Compliant; Schedule B: 8541100080, 8541100080|8541100080|8541100080|8541100080|8541100080; Stock: 401; Supplier: Farnell; Supplier Part Number: 3774560; Library Name: IMCODR Components.SchLib
